# Altium schematic sheet 01.Under_voltage_detection.SchDoc
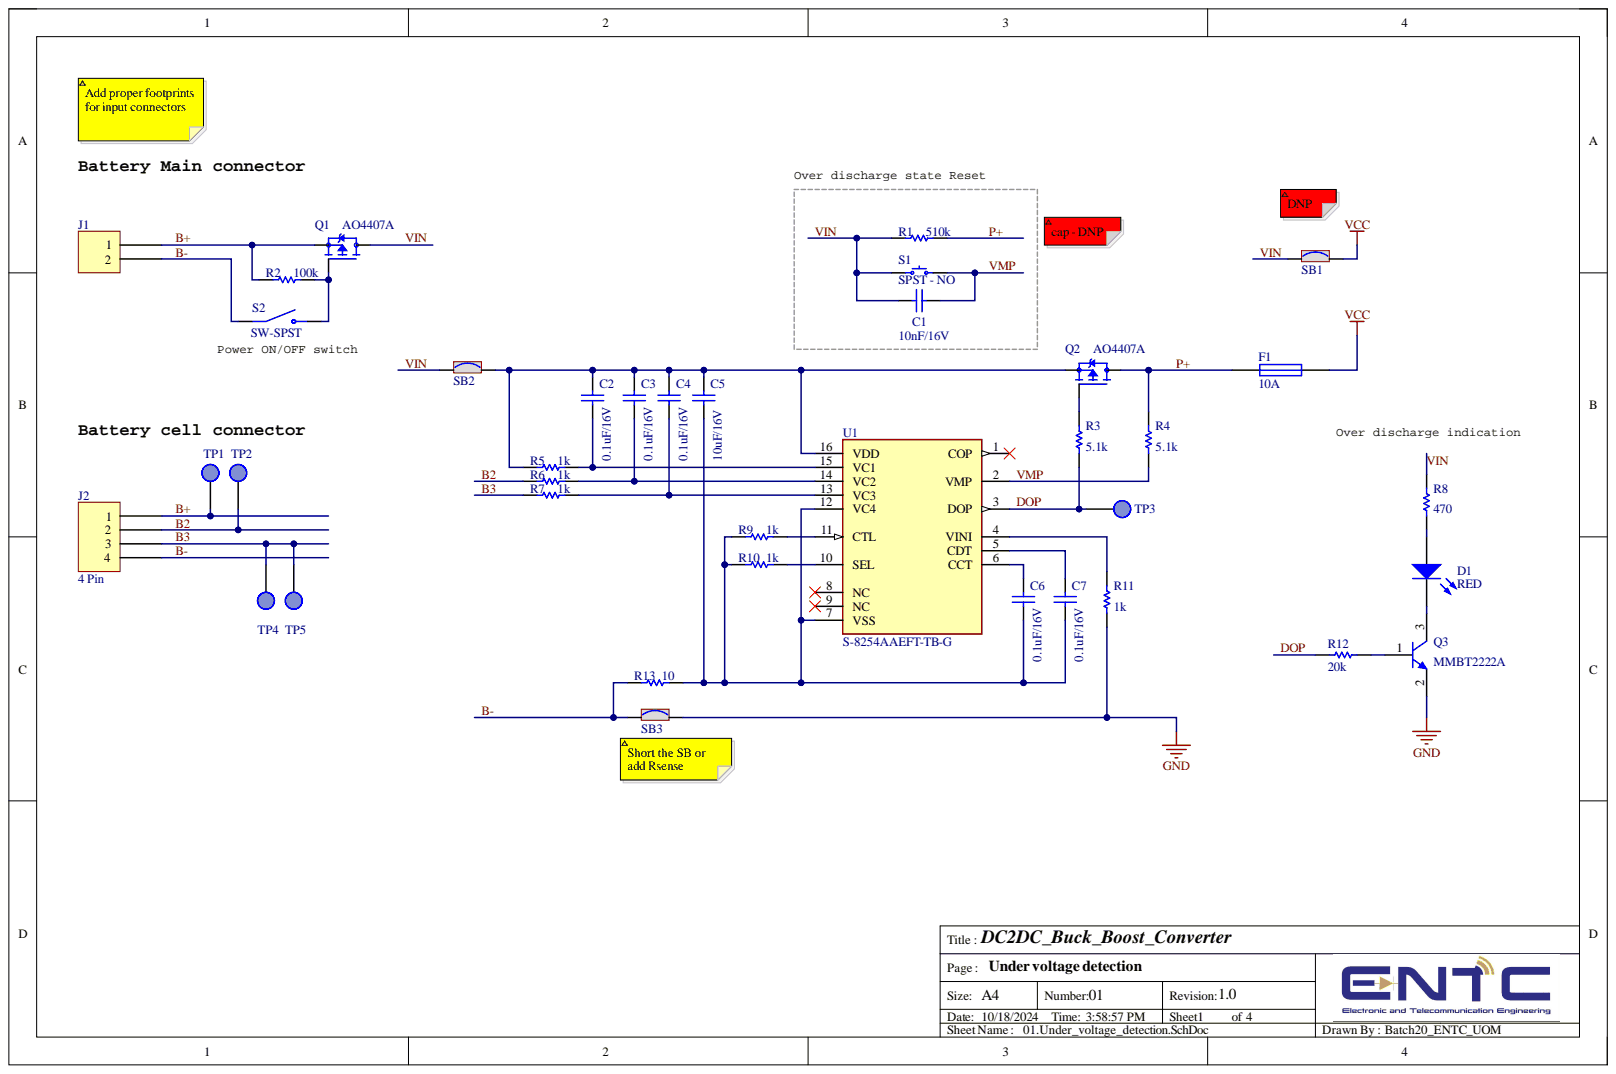
[diagram p0: 01.Under_voltage_detection.SchDoc]

## Parts
C1  10nF/16V  [cap0805]
C2  0.1uF/16V  [cap0805]
C3  0.1uF/16V  [cap0805]
C4  0.1uF/16V  [cap0805]
C5  10uF/16V  [cap0805]
C6  0.1uF/16V  [cap0805]
C7  0.1uF/16V  [cap0805]
D1  RED  [LED0805]
F1  10A  [FUSE_4245]
J1  (no value)  [JST XH B2B-XH-A]
J2  4 Pin  [JST XH B4B-XH-A]
Q1  AO4407A  [SOIC127P600X175-8N]
Q2  AO4407A  [SOIC127P600X175-8N]
Q3  MMBT2222A  [SOT23]
R1  510k  [RES0805]
R2  100k  [RES0805]
R3  5.1k  [RES0805]
R4  5.1k  [RES0805]
R5  1k  [RES0805]
R6  1k  [RES0805]
R7  1k  [RES0805]
R8  470  [RES0805]
R9  1k  [RES0805]
R10  1k  [RES0805]
R11  1k  [RES0805]
R12  20k  [RES0805]
R13  10  [RES0805]
S1  SPST - NO  [4312560387x6]
S2  SW-SPST  [SW_TL2230EEF100]
SB1  SB_ON  [SOLDER-BRIDGE_R1210-0R]  (value not drawn)
SB2  SB_ON  [SOLDER-BRIDGE_R0805-0R]  (value not drawn)
SB3  SB_ON  [SOLDER-BRIDGE_R0805-0R]  (value not drawn)
TP1  (no value)  [tp]  (no BOM)
TP2  (no value)  [tp]  (no BOM)
TP3  (no value)  [tp]  (no BOM)
TP4  (no value)  [tp]  (no BOM)
TP5  (no value)  [tp]  (no BOM)
U1  S-8254AAEFT-TB-G  [SOP65P640X110-16N]

## Nets
GND: Q3.2 R11.1 SB3.2
VCC: F1.2 SB1.2
B2: J2.2 R6.1 TP2.1
B3: J2.3 R7.1 TP4.1 TP5.1
B+: J1.1 J2.1 Q1.1 R2.1 TP1.1
B-: J1.2 J2.4 R13.1 S2.2 SB3.1
DOP: R3.1 R12.2 TP3.1 U1.3(DOP)
P+: F1.1 Q2.5 R1.1 R4.2
VIN: C1.2 Q1.5 R1.2 R8.2 S1.1 SB1.1 SB2.1
VMP: C1.1 R4.1 S1.2 U1.2(VMP)
NetC2_1: C2.1 R5.2 U1.15(VC1)
NetC2_2: C2.2 C3.2 C4.2 C5.2 Q2.1 R5.1 SB2.2 U1.16(VDD)
NetC3_1: C3.1 R6.2 U1.14(VC2)
NetC4_1: C4.1 R7.2 U1.13(VC3)
NetC5_1: C5.1 C6.1 C7.1 R9.1 R10.1 R13.2 U1.7(VSS) U1.12(VC4)
NetC6_2: C6.2 U1.6(CCT)
NetC7_2: C7.2 U1.5(CDT)
NetD1_1: D1.1 R8.1
NetD1_2: D1.2 Q3.3
NetQ1_4: Q1.4 R2.2 S2.3
NetQ2_4: Q2.4 R3.2
NetQ3_1: Q3.1 R12.1
NetR9_2: R9.2 U1.11(CTL)
NetR10_2: R10.2 U1.10(SEL)
NetR11_2: R11.2 U1.4(VINI)

Not connected: U1 1(COP) 8(NC) 9(NC)

## Library data of the parts
C1-C7 = Cap: Capacitor; Component Kind: Standard; Library Name: Altium_Main_Library.SchLib
D1 = LED: SMD LED 0805 Red; Component Kind: Standard; Library Name: Altium_Main_Library.SchLib
F1 = Fuse: 10A Glass fuse; Component Kind: Standard; Library Name: Altium_Main_Library.SchLib
J1 = NC6-P108-02: CONN BARRIER STRIP 2CIRC 0.375"; Component Kind: Standard; Library Name: Altium_Main_Library.SchLib
J2 = NC6-P108-04: CONN BARRIER STRIP 4CIRC 0.375"; Component Kind: Standard; Library Name: Altium_Main_Library.SchLib
Q1 Q2 = AO4407A: P-Channel 30V 12A _Ta_ 3.1W _Ta_ Surface Mount 8-SOIC; Component Kind: Standard; Library Name: DC2DC_Buck_Boost_Converter_SchLib.SchLib; MP: AO4407A
Q3 = NPN: NPN Bipolar Transistor; Component Kind: Standard; Library Name: Altium_Main_Library.SchLib
R1-R13 = Res: Resistor 0805; Component Kind: Standard; Library Name: Altium_Main_Library.SchLib
S1 = SPST-NO 4 PIN: Switch Push button; Component Kind: Standard; Library Name: DC2DC_Buck_Boost_Converter_SchLib.SchLib
SB1-SB3 = SB_ON: Solder Bridge ON; MP: PMR03EZPJ000; Component Kind: Standard; Library Name: Altium_Main_Library.SchLib
TP1-TP5 = TP: Test Point; Library Name: Altium_Main_Library.SchLib
U1 = S-8254AAEFT-TB-G: Battery Battery Protection IC Lithium Ion/Polymer 16-TSSOP; Component Kind: Standard; Library Name: DC2DC_Buck_Boost_Converter_SchLib.SchLib
S2 = SW-SPST: Component Kind: Standard; Library Name: DC2DC_Buck_Boost_Converter_SchLib.SchLib
